# Altium schematic sheet Sensors.SchDoc
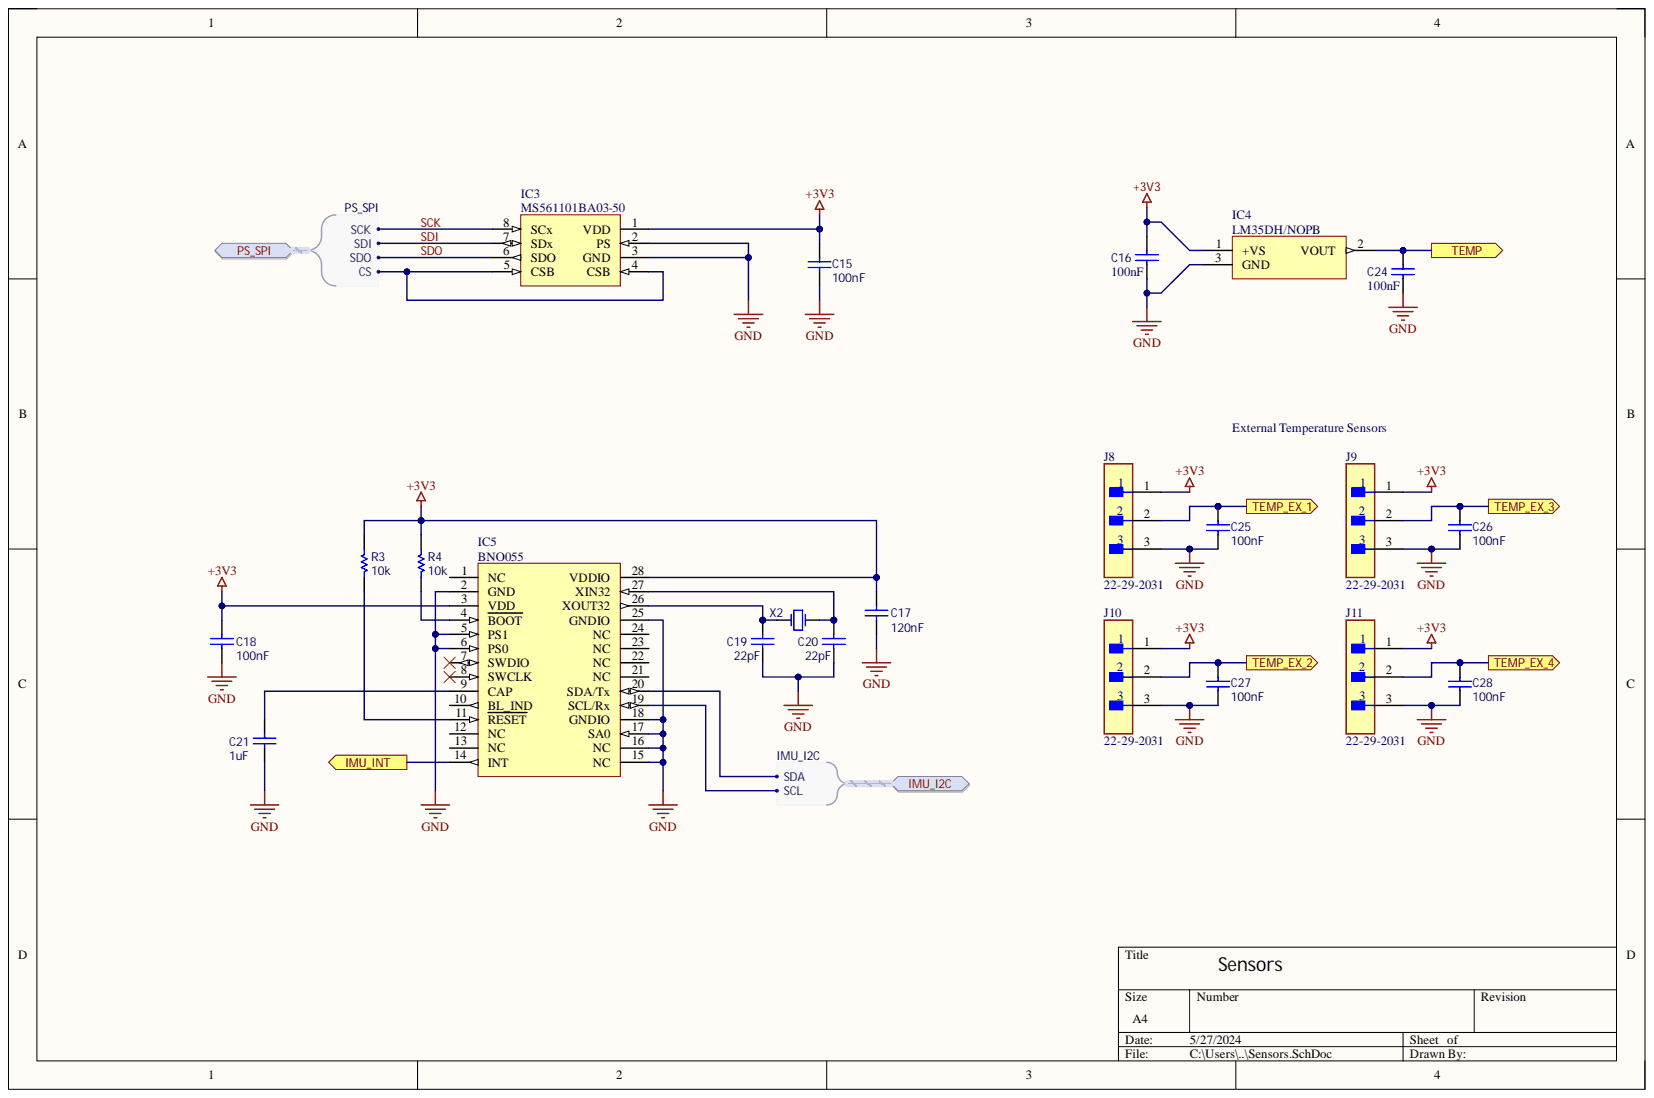
[diagram p0: Sensors.SchDoc]

## Parts
C15  value 100nF  Capacitance: 100nF  [CAPC1608X10N]  (hidden comment: C0603C104M5RACTU)
C16  value 100nF  Capacitance: 100nF  [CAPC1608X10N]  (hidden comment: C0603C104M5RACTU)
C17  value 120nF  Capacitance: 120nF  [CAPC1608X10N]  (hidden comment: C0603C124K4RACTU)
C18  value 100nF  Capacitance: 100nF  [CAPC1608X10N]  (hidden comment: C0603C104M5RACTU)
C19  value 22pF  Capacitance: 22pF  [CAPC1005X05N]  (hidden comment: C0402C220K5GACTU)
C20  value 22pF  Capacitance: 22pF  [CAPC1005X05N]  (hidden comment: C0402C220K5GACTU)
C21  value 1uF  Capacitance: 1uF  [CAPC1608X10N]  (hidden comment: C0603C105K8PACTU)
C24  value 100nF  Capacitance: 100nF  [CAPC1608X10N]  (hidden comment: C0603C104M5RACTU)
C25  value 100nF  Capacitance: 100nF  [CAPC1608X10N]  (hidden comment: C0603C104M5RACTU)
C26  value 100nF  Capacitance: 100nF  [CAPC1608X10N]  (hidden comment: C0603C104M5RACTU)
C27  value 100nF  Capacitance: 100nF  [CAPC1608X10N]  (hidden comment: C0603C104M5RACTU)
C28  value 100nF  Capacitance: 100nF  [CAPC1608X10N]  (hidden comment: C0603C104M5RACTU)
IC3  MS561101BA03-50  [MS561101BA03-50-Footprint-1]
IC4  LM35DH/NOPB  [LM35DH_NOPB-Footprint-1]
IC5  BNO055  [BNO055-Footprint-1]
J8  22-29-2031  [FP-22-29-2031-MFG]
J9  22-29-2031  [FP-22-29-2031-MFG]
J10  22-29-2031  [FP-22-29-2031-MFG]
J11  22-29-2031  [FP-22-29-2031-MFG]
R3  value 10k  [RESC1608X06N]  (hidden comment: CRCW060310K0FKEA)
R4  value 10k  [RESC1608X06N]  (hidden comment: CRCW060310K0FKEA)
X2  ABS07-32.768KHZ-T  [ABS07-32.768KHZ-T-Footprint-1]  (value not drawn)

## Ports
IMU_I2C, IMU_I2C.SCL, IMU_I2C.SDA, IMU_INT, PS_SPI, PS_SPI.CS, PS_SPI.SCK, PS_SPI.SDI, PS_SPI.SDO, TEMP, TEMP_EX_1, TEMP_EX_2, TEMP_EX_3, TEMP_EX_4

## Nets
+3V3: C15.2 C16.2 C17.2 C18.2 IC3.1(VDD) IC4.1 IC5.3(VDD) IC5.28(VDDIO) J8.1 J9.1 J10.1 J11.1 R3.2 R4.2
GND: C15.1 C16.1 C17.1 C18.1 C19.1 C20.1 C21.1 C24.1 C25.1 C26.1 C27.1 C28.1 IC3.2(PS) IC3.3(GND) IC4.3 IC5.2(GND) IC5.5(PS1) IC5.6(PS0) IC5.15(NC) IC5.16(NC) IC5.17(SA0) IC5.18(GNDIO) IC5.25(GNDIO) J8.3 J9.3 J10.3 J11.3
IMU_INT: IC5.14(INT) ; port IMU_INT
PS_SPI: IC3.4(CSB) IC3.5(CSB) ; port PS_SPI
SCK: IC3.8(SCx) ; port PS_SPI
SDI: IC3.7(SDx) ; port PS_SPI
SDO: IC3.6(SDO) ; port PS_SPI
TEMP: C24.2 IC4.2 ; port TEMP
TEMP_EX_1: C25.2 J8.2 ; port TEMP_EX_1
TEMP_EX_2: C27.2 J10.2 ; port TEMP_EX_2
TEMP_EX_3: C26.2 J9.2 ; port TEMP_EX_3
TEMP_EX_4: C28.2 J11.2 ; port TEMP_EX_4
NetC19_2: C19.2 IC5.26(XOUT32) X2.1
NetC20_2: C20.2 IC5.27(XIN32) X2.2
NetC21_2: C21.2 IC5.9(CAP)
NetIC5_1: IC5.1(NC)
NetIC5_4: IC5.4(BOOT) R4.1
NetIC5_7: IC5.7(SWDIO)
NetIC5_8: IC5.8(SWCLK)
NetIC5_10: IC5.10(BL_IND)
NetIC5_11: IC5.11(RESET) R3.1
NetIC5_12: IC5.12(NC)
NetIC5_13: IC5.13(NC)
NetIC5_19: IC5.19(SCL/Rx) ; port IMU_I2C
NetIC5_20: IC5.20(SDA/Tx) ; port IMU_I2C
NetIC5_21: IC5.21(NC)
NetIC5_22: IC5.22(NC)
NetIC5_23: IC5.23(NC)
NetIC5_24: IC5.24(NC)

## Library data of the parts
C15 C16 C18 C24-C28 = CMP-00038-5: Cap Ceramic 0.1uF 50V X7R 20% SMD 0603 125C T/R; Dissipation Factor: 2.5%; Case Code (Imperial): 0603; Case Code (Metric): 1608; Case/Package: 0603; Component Kind: Standard; Contact Plating: Tin,Matte; Depth: 0.8mm; Dielectric: X7R; Dielectric Material: Ceramic; Height: 0.8mm; JLC_PN: C14663; Lead Pitch: 0.7mm; Length: 1.6mm; Max Operating Temperature: 125°C; Min Operating Temperature: -55°C; Mount: Surface Mount,PCB; Package Quantity: 1; Packaging: Tape and Reel; Radiation Hardening: No; REACH SVHC: No SVHC; Termination: SMD/SMT; Thickness: 0.034inch; Tolerance: 20%; Voltage Rating: 50V; Voltage Rating (DC): 50V; Width: 0.031inch; Library Name: UWA Aerospace
C17 = CMP-00213-1: MLCC CAPACITOR, 0.12UF, 16V, X7R, 10%, 0603, FULL REEL; Product Range:C Series; Capacitance:0.12 F; Capacitance Tolerance: 10%; Dielectric Characteristic:X7R; Voltage Rating:16V; Ceramic Capacitor Case:0603 [1608 Metric] ;RoHS Compliant: Yes; Dissipation Factor: 3.5%; Case Code (Imperial): 0603; Case Code (Metric): 1608; Case/Package: 0603; Component Kind: Standard; Depth: 0.8mm; Dielectric: X7R; Dielectric Material: Ceramic; Height: 0.8mm; Lead Pitch: 0.7mm; Length: 1.6mm; Max Operating Temperature: 125°C; Min Operating Temperature: -55°C; Mount: Surface Mount; Package Quantity: 1; Packaging: Tape and Reel; Radiation Hardening: No; REACH SVHC: No SVHC; RoHS Compliant: Yes; Series: C; Termination: SMD/SMT; Thickness: 0.034inch; Tolerance: 10%; Voltage Rating: 16V; Voltage Rating (DC): 16V; Width: 0.031inch; Library Name: UWA Aerospace
C19 C20 = CMP-00083-1: CAP CER 22PF 50V C0G/NP0 0402; Dielectric: C0G; Dielectric Material: Ceramic; Dissipation Factor: 0.1%; Tolerance: 10%; Case Code (Imperial): 0402; Case Code (Metric): 1005; Case/Package: 0402; Component Kind: Standard; Depth: 0.5mm; Height: 0.5mm; Lead Free: Lead Free; Lead Pitch: 0.3mm; Length: 1mm; Max Operating Temperature: 125°C; Min Operating Temperature: -55°C; Mount: Surface Mount; Package Quantity: 1; Packaging: Tape and Reel; Radiation Hardening: No; REACH SVHC: No SVHC; Termination: SMD/SMT; Thickness: 0.022inch; Voltage Rating: 50V; Voltage Rating (DC): 50V; Width: 0.02inch; Library Name: UWA Aerospace
C21 = CMP-00045-2: Cap Ceramic 1uF 10V X5R 10% SMD 0603 85°C Paper T/R; Case Code (Imperial): 0603; Case Code (Metric): 1608; Case/Package: 0603; Component Kind: Standard; Depth: 0.8mm; Dielectric: X5R; Dielectric Material: Ceramic; Height: 0.8mm; JLC_PN: C15849; Lead Free: Lead Free; Length: 1.6mm; Max Operating Temperature: 85°C; Min Operating Temperature: -55°C; Mount: Surface Mount; Number of Pins: 2; Package Quantity: 1; Packaging: Tape and Reel; Radiation Hardening: No; REACH SVHC: No SVHC; Termination: SMD/SMT; Thickness: 0.035inch; Tolerance: 10%; Voltage: 10V; Voltage Rating: 10V; Voltage Rating (DC): 10V; Weight: 7.1E-05oz; Width: 0.031inch; Library Name: UWA Aerospace
IC3 = CMP-00182-2: SENSOR SOLUTIONS - TE CONNECTIVITY - MS561101BA03-50 - MS5611-BT M-CAPS 3X5X1MM T&R / T&R; Max Pressure: 87.02PSI; Operating Pressure: 17.4PSI; Operating Supply Voltage: 3.6V; Output Type: SPI,I2C; Port Style: No Port; Pressure Type: Absolute; Case/Package: SMD/SMT; Component Kind: Standard; Lead Free: Lead Free; Max Operating Temperature: 85°C; Min Operating Temperature: -40°C; Number of Pins: 8; Termination: SMD/SMT; Library Name: UWA Aerospace
IC4 = CMP-00206-1: TEXAS INSTRUMENTS LM35DH/NOPB Temperature Sensor IC, Voltage,  0.6C, 0 C, +100 C, TO-46, 3 Pins; Interface: Analog; Max Supply Voltage: 30V; Min Supply Voltage: 4V; Nominal Supply Current: 56.2uA; Output Current: 10mA; Output Type: Analog Voltage,Voltage,Analog; Output Voltage: 6V; Quiescent Current: 56uA; Case/Package: TO-46; Component Kind: Standard; Contact Plating: Copper,Tin,Silver; Lead Free: Lead Free; Max Operating Temperature: 110°C; Min Operating Temperature: -40°C; Mount: Through Hole; Number of Pins: 3; Package Quantity: 1000; Packaging: Bulk; Radiation Hardening: No; REACH SVHC: No SVHC; Library Name: UWA Aerospace
IC5 = CMP-00179-4: IMU ACCEL/GYRO/MAG I2C 28LGA; Sensor Type: Accelerometer,Gyroscope,Magnetometer,3 Axis; Case/Package: LGA; Component Kind: Standard; JLC_PN: C93216; Lead Free: Lead Free; Max Operating Temperature: 85°C; Min Operating Temperature: -40°C; Mount: Surface Mount; Output Type: I2C; Packaging: Tape and Reel; Library Name: UWA Aerospace
J8-J11 = CMP-04776-000327-1: CONN HEADER VERT 3POS 2.54MM; Connector Type: Male Pin; Contact Resistance: 10mR; Current Rating: 4A; Gender: Male; Number of Contacts: 3; Voltage Rating: 250V; Color: Natural; Component Kind: Standard; Contact Material: Brass; Contact Mating Length: 0.295inch; Contact Plating: Gold; Contact Type: Male Pin; Depth: 5.8mm; Fastening Type: Friction; Housing Color: White; Housing Material: Nylon; Lead Pitch: 2.54mm; Length: 7.62mm; Library Name: Altium Content Vault; Max Operating Temperature: 75°C; Min Operating Temperature: 0°C; Mount: Through Hole; Number of Positions: 3; Number of Rows: 1; Orientation: Straight; Packaging: Bulk; Pitch: 2.54mm; Radiation Hardening: No; RoHS Compliant: Yes; Series: KK® 6410; Termination: Solder; Working Voltage: 250V
R3 R4 = CMP-00042-5: VISHAY - CRCW060310K0FKEA - SMD Chip Resistor, 0603 [1608 Metric], 10 kohm, CRCW e3 Series, 75 V, Thick Film, 100 mW; Max Power Dissipation: 100mW; Resistance: 10kR; Temperature Coefficient: 100ppm/°C; Tolerance: 1%; Voltage Rating: 75V; Case Code (Imperial): 0603; Case Code (Metric): 1608; Case/Package: 0603; Component Kind: Standard; Composition: Thick Film; Contact Plating: Tin,Lead; Depth: 0.85mm; Features: Automotive AEC-Q200; Height: 0.45mm; JLC_PN: C25804; Lead Free: Lead Free; Length: 1.55mm; Max Operating Temperature: 155°C; Military Standard: Not; Min Operating Temperature: -55°C; Number of Pins: 2; Number of Terminations: 2; Package Quantity: 10; Packaging: Tape and Reel; Power Rating: 100mW; Radiation Hardening: No; REACH SVHC: Unknown; Termination: SMD/SMT; Voltage Rating (DC): 75V; Weight: 7.1E-05oz; Width: 0.033inch; Library Name: UWA Aerospace
X2 = CMP-00187-2: Crystal; Ulta-Mini; 3.2 x 1.5 x 0.9mm; 32.768KHZ; 12.5pf; 20ppm -40+85C; SMD; T/R; ESR (Equivalent Series Resistance): 70kR; Case/Package: CSMD; Component Kind: Standard; Depth: 1.5mm; Frequency: 32.768kHz; Frequency Tolerance: 20ppm; Height: 0.035inch; JLC_PN: C130253; Lead Free: Lead Free; Length: 3.2mm; Load Capacitance: 12.5pF; Max Operating Temperature: 85°C; Min Operating Temperature: -40°C; Number of Pins: 2; Package Quantity: 3000; Packaging: Tape and Reel; Radiation Hardening: No; REACH SVHC: No SVHC; Series Resistance: 70kR; Termination: SMD/SMT; Tolerance: 20ppm; Width: 0.061inch; Library Name: UWA Aerospace
